# Altium schematic sheet PWM_Driver.SchDoc(PWM_Driver)
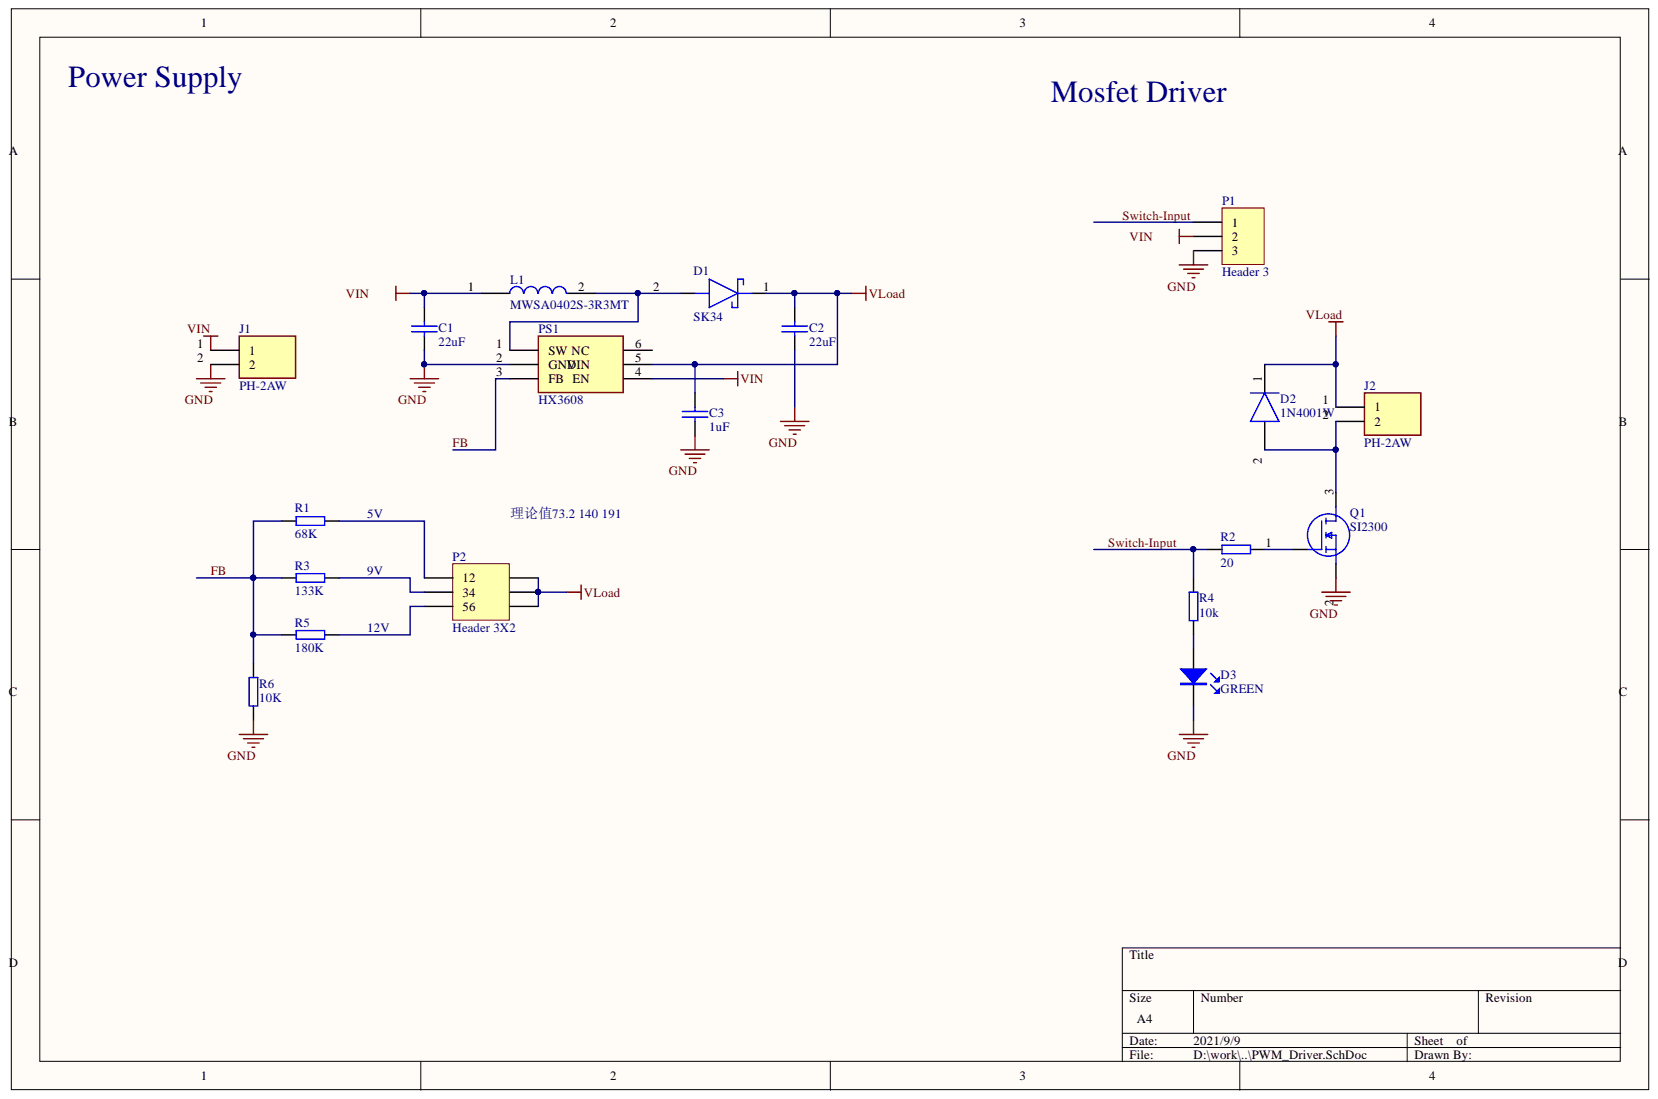
[diagram p0: PWM_Driver.SchDoc]

## Parts
C1  22uF  [C1206]
C2  22uF  [C1206]
C3  1uF  [C0603]
D1  SK34  [DIOM5436X244N]
D2  1N4001W  [1N4001W]
D3  GREEN  [LED_0603]
J1  PH-2AW  [SHDR2W50P0X200_1X2_600X600X500P]
J2  PH-2AW  [SHDR2W50P0X200_1X2_600X600X500P]
L1  MWSA0402S-3R3MT  [INDPM4442X200N]
P1  Header 3  [HDR1X3]
P2  Header 3X2  [HDR2X3]
PS1  HX3608  [SOT95P280X125-6N]
Q1  SI2300  [SI2302]
R1  68K  [R0603]
R2  20  [R0603]
R3  133K  [R0603]
R4  10k  [R0603]
R5  180K  [R0603]
R6  10K  [R0603]

## Nets
GND: C1.1 C2.1 C3.1 D3.2 J1.2 P1.3 PS1.2(GND) Q1.2 R6.2
VIN: C1.2 J1.1 L1.1 P1.2 PS1.4
VLOAD: C2.2 C3.2 D1.1 D2.1 J2.1 P2.2 P2.4 P2.6 PS1.5
FB: PS1.3(FB) R1.1 R3.1 R5.1 R6.1
SWITCH-INPUT: P1.1 R2.1 R4.1
NetD1_2: D1.2 L1.2 PS1.1(SW_NC)
NetD2_2: D2.2 J2.2 Q1.3
NetD3_1: D3.1 R4.2
NetP2_1: P2.1 R1.2
NetP2_3: P2.3 R3.2
NetP2_5: P2.5 R5.2
NetQ1_1: Q1.1 R2.2

Not connected: PS1 6

## Library data of the parts
C1 C2 = CAP: 25V X5R 10% GRM31CR61E226KE15L; Component Kind: Standard; Library Name: Component.SchLib
C3 = CAP: 25V X5R; Component Kind: Standard; Library Name: Component.SchLib
D1 = SK34: Schottky Diode; Manufacturer_Name: MDD; Component Kind: Standard; Datasheet Link: https://datasheet.lcsc.com/szlcsc/1903041730_MDD-Jiangsu-Yutai-Elec-SK34_C18895.pdf; Height: 2.44mm; Manufacturer_Part_Number: SK34
D2 = 1N4001W: Diode; Manufacturer_Name: Shandong Jingdao Microelectronics; Component Kind: Standard; Datasheet Link: https://datasheet.lcsc.com/szlcsc/Shandong-Jingdao-Microelectronics-1N4001W_C162732.pdf; Height: 1.1mm; Library Name: SamacSys.SchLib; Manufacturer_Part_Number: 1N4001W
D3 = LED: Component Kind: Standard; Description: LED; Library Name: Component.SchLib
J1 J2 = PH-2AW: Connector; Manufacturer_Name: Boom Precision Electric; Component Kind: Standard; Datasheet Link: https://lcsc.com/product-detail/Wire-To-Board-Wire-To-Wire-Connector_Boom-Precision-Elec-PH-2AW-2P-pitch2-0mm-Lying-in_C16965.html; Height: 5mm; Manufacturer_Part_Number: PH-2AW
L1 = MWSA0402S-3R3MT: Inductor; Manufacturer_Name: SUNLORD; Component Kind: Standard; Datasheet Link: https://datasheet.lcsc.com/szlcsc/Sunlord-MWSA1004-3R3MT_C143963.pdf; Height: 2mm; Library Name: SamacSys.SchLib; Manufacturer_Part_Number: MWSA0402S-3R3MT
P1 = Header 3: Header, 3-Pin; Component Kind: Standard; LatestRevisionDate: 17-Jul-2002; LatestRevisionNote: Re-released for DXP Platform.; Library Name: Miscellaneous Connectors.IntLib; Signal Integrity: Connector; Publisher: Altium Limited
P2 = Header 3X2: Header, 3-Pin, Dual row; Component Kind: Standard; LatestRevisionDate: 17-Jul-2002; LatestRevisionNote: Re-released for DXP Platform.; Library Name: Miscellaneous Connectors.IntLib; Signal Integrity: Connector; Publisher: Altium Limited
PS1 = HX3608: Power Supply; Manufacturer_Name: hengjiaxing; Component Kind: Standard; Datasheet Link: https://datasheet.lcsc.com/szlcsc/1811201211_hengjiaxing-HX3608_C296278.pdf; Height: 1.25mm; Manufacturer_Part_Number: HX3608
Q1 = SI2300: MOSFET (N-Channel); Manufacturer_Name: Hottech; Component Kind: Standard; Datasheet Link: https://atta.szlcsc.com/upload/public/pdf/source/20200416/C181085_A8D06F9BC66C1A37477B8C3A2BB74F6D.pdf; Height: 1.005mm; Library Name: SamacSys.SchLib; Manufacturer_Part_Number: SI2300
R1 R3 R5 = RES: X5R 0603 1% 1/16W; Component Kind: Standard; Library Name: Component.SchLib
R2 R4 = RES: 电阻; Component Kind: Standard; Library Name: Component.SchLib
R6 = RES: X7R 0603 1% 1/16W; Component Kind: Standard; Library Name: Component.SchLib
